# Altium schematic sheet Schematic_Rev1.1.SchDoc
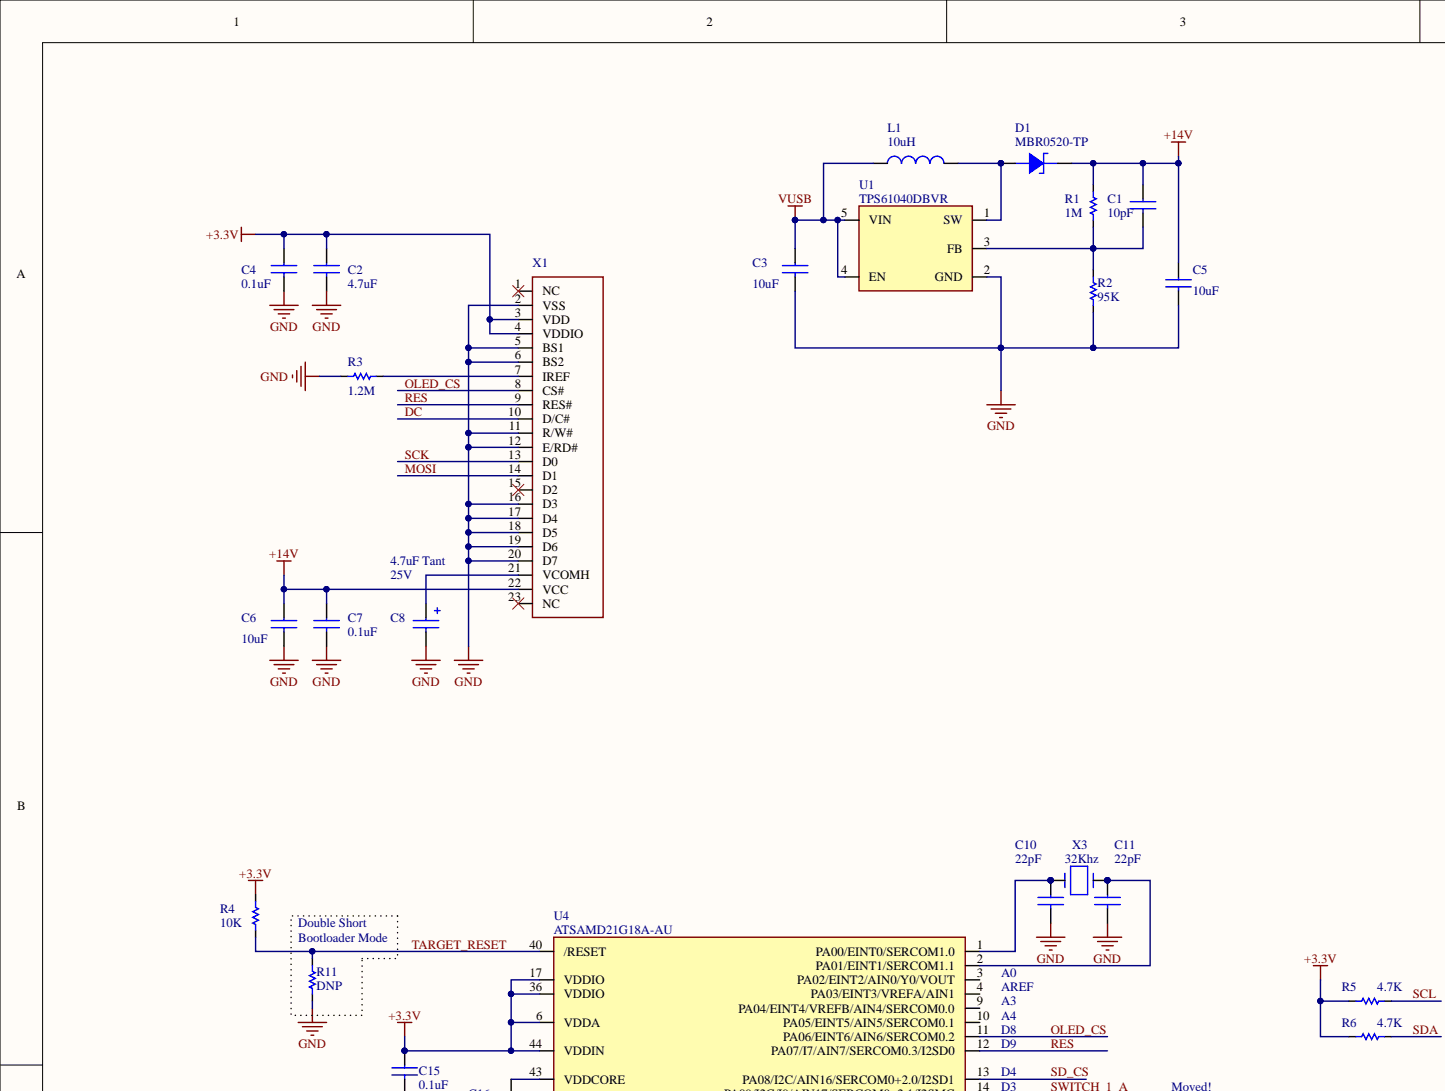
[diagram p0: Schematic_Rev1.1.SchDoc, top left]
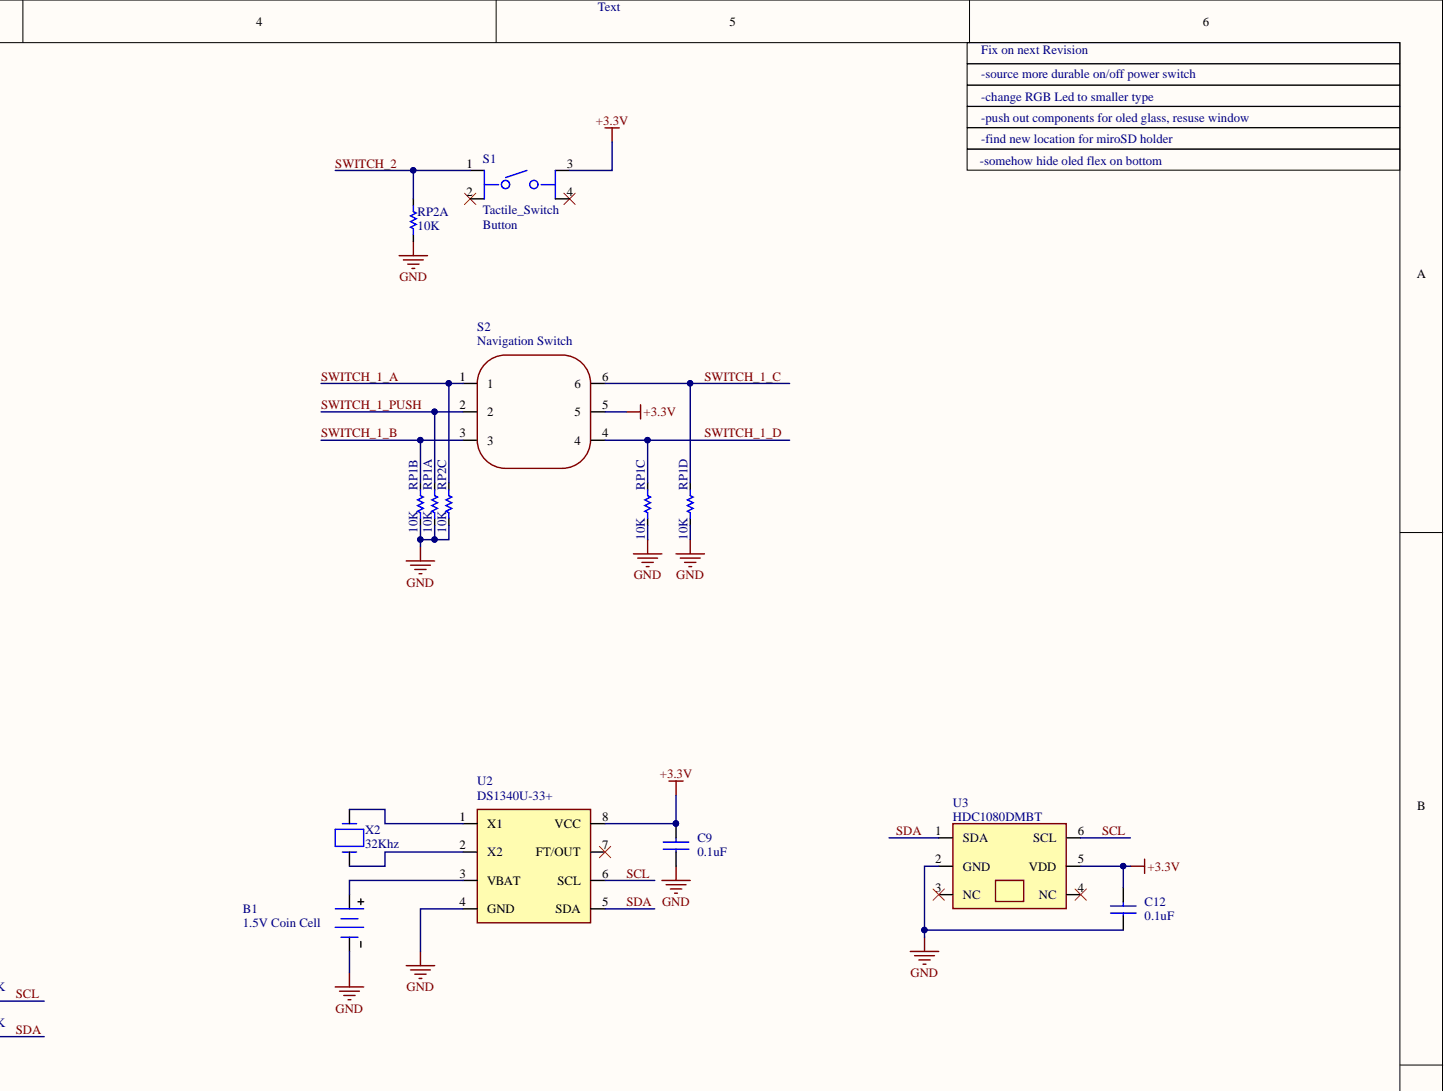
[diagram p1: Schematic_Rev1.1.SchDoc, top right]
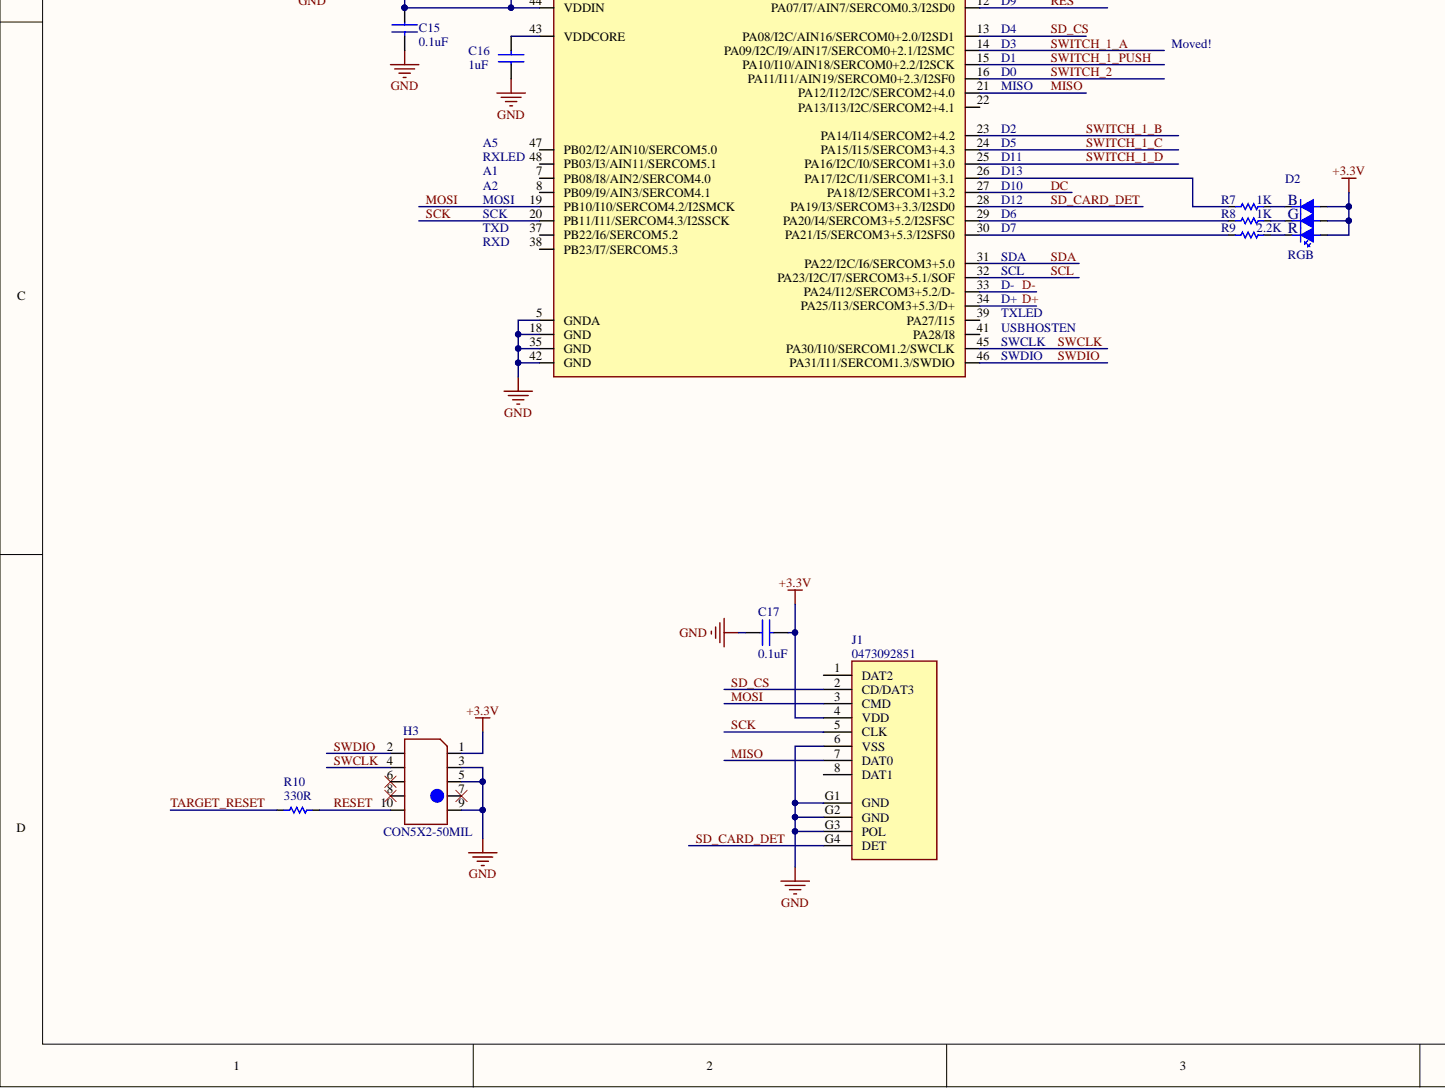
[diagram p2: Schematic_Rev1.1.SchDoc, bottom left]
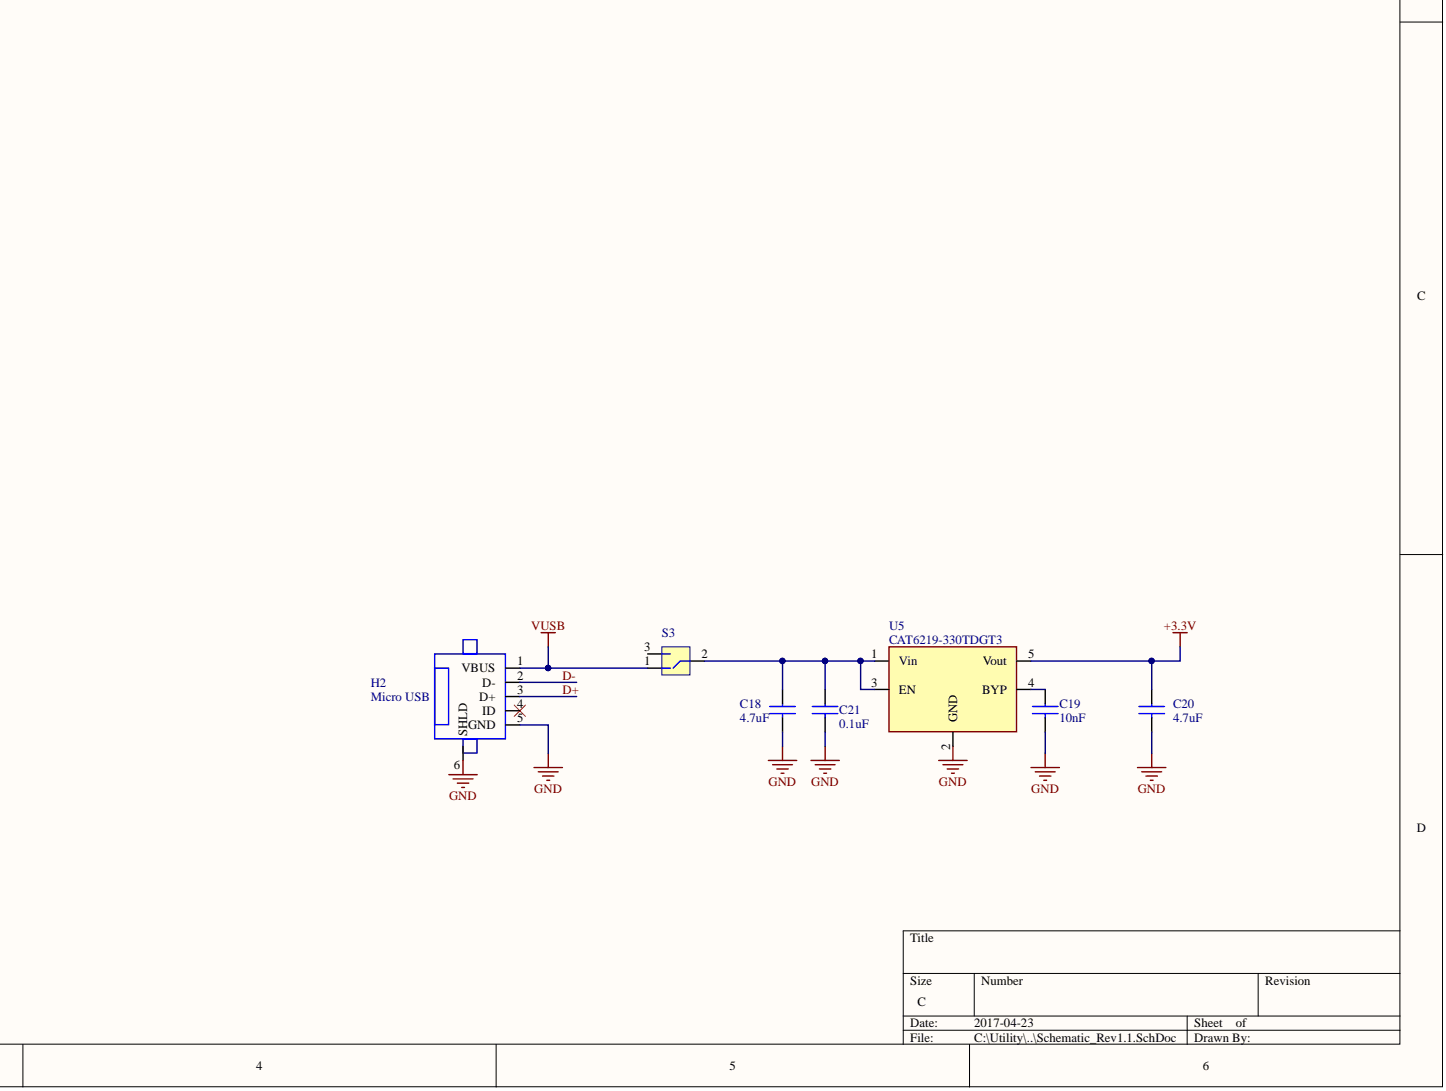
[diagram p3: Schematic_Rev1.1.SchDoc, bottom right]

## Parts
B1  value 1.5V Coin Cell  [CR377 Mount]  (hidden comment: CR377)  (no BOM)
C1  10pF  [CAPC1608X09L]
C2  4.7uF  [CAPC3216X160L]
C3  10uF  [CAPC3216X160L]
C4  0.1uF  [CAPC1608X09L]
C5  10uF  [CAPC3216X160L]
C6  10uF  [CAPC3216X160L]
C7  0.1uF  [CAPC1608X09L]
C8  4.7uF Tant  [CAPC3216X160N_POL]
C9  0.1uF  [CAPC1608X09L]
C10  22pF  [CAPC1608X09L]
C11  22pF  [CAPC1608X09L]
C12  0.1uF  [CAPC1608X09L]
C15  0.1uF  [CAPC1608X09L]
C16  1uF  [CAPC1608X09L]
C17  0.1uF  [CAPC1608X09L]
C18  4.7uF  [CAPC3216X160L]
C19  10nF  [CAPC1608X09L]
C20  4.7uF  [CAPC3216X160L]
C21  0.1uF  [CAPC1608X09L]
D1  MBR0520-TP  [SOD123]
D2  RGB  [E-09-034]
H2  Micro USB  [1981584-1]
H3  CON5X2-50MIL  [HDR5X2-50MIL-SMT]  (no BOM)
J1  0473092851  [0473092851]
L1  value 10uH  [LHQ43C]  (hidden comment: LQH43CN100K03L)
R1  1M  [RESC1608X06L]
R2  95K  [RESC1608X06L]
R3  1.2M  [RESC1608X06L]
R4  10K  [RESC1608X06L]
R5  4.7K  [RESC1608X06L]
R6  4.7K  [RESC1608X06L]
R7  1K  [RESC1608X06L]
R8  1K  [RESC1608X06L]
R9  2.2K  [RESC1608X06L]
R10  330R  [RESC1608X06L]
R11  DNP  [RESC1608X06L]  (no BOM)
RP1  value 10K  [EXB38V]  (hidden comment: EXB38V_SEPERATED)  (parts A,B,C,D)
RP2  value 10K  [EXB38V]  (hidden comment: EXB38V_SEPERATED)  (parts A,C)
S1  Tactile_Switch  value Button  [FSM6JSMA]
S2  Navigation Switch  [NAVIGATION - DX]
S3  SWITCH SLIDE  [SLIDE_SWITCH_JS102000SAQN]  (value not drawn)
U1  TPS61040DBVR  [SOT95P280X144-5N]
U2  DS1340U-33+  [TSOP65P490X110-8N]
U3  HDC1080DMBT  [S-PWSON-N6]
U4  ATSAMD21G18A-AU  [LQFP48]
U5  CAT6219-330TDGT3  [SOT95P280X144-5N]
X1  OLED_GLASS 0.95 inch  [OLED_GLASS 0.96 INCH - new]  (value not drawn)
X2  value 32Khz  [ECS-.327-12.5-34B]  (hidden comment: ECS-.327-12.5-34B)
X3  value 32Khz  [ECS-.327-12.5-34B]  (hidden comment: ECS-.327-12.5-34B)

## Nets
+3.3V: C2.1 C4.1 C9.2 C12.2 C15.1 C17.2 C20.1 D2.4(R) D2.5(G) D2.6 H3.1 J1.4(VDD) R4.1 R5.2 R6.2 S1.3 S2.5 U2.8(VCC) U3.5(VDD) U4.6(VDDA) U4.17(VDDIO) U4.36(VDDIO) U4.44(VDDIN) U5.5(Vout) X1.3(VDD) X1.4(VDDIO)
+14V: C1.1 C5.1 C6.1 C7.1 D1.1 R1.1 X1.22(VCC)
GND: B1.2 C2.2 C3.2 C4.2 C5.2 C6.2 C7.2 C8.2 C9.1 C10.2 C11.2 C12.1 C15.2 C16.1 C17.1 C18.2 C19.2 C20.2 C21.2 H2.5(GND) H2.6(SHLD) H3.3 H3.5 H3.9 J1.6(VSS) J1.G1(GND) J1.G2(GND) J1.G3(POL) R2.2 R3.1 R11.2 RP1.5 RP1.6 RP1.7 RP1.8 RP2.1 RP2.6 U1.2(GND) U2.4(GND) U3.2(GND) U4.5(GNDA) U4.18(GND) U4.35(GND) U4.42(GND) U5.2(GND) X1.2(VSS) X1.5(BS1) X1.6(BS2) X1.11(R/W#) X1.12(E/RD#) X1.16(D3) X1.17(D4) X1.18(D5) X1.19(D6) X1.20(D7)
VUSB: C3.1 H2.1(VBUS) L1.1 S3.1 U1.4(EN) U1.5(VIN)
D+: H2.3(D+) U4.34(PA25/I13/SERCOM3+5.3/D+)
D-: H2.2(D-) U4.33(PA24/I12/SERCOM3+5.2/D-)
DC: U4.27(PA18/I2/SERCOM1+3.2) X1.10(D/C#)
MISO: J1.7(DAT0) U4.21(PA12/I12/I2C/SERCOM2+4.0)
MOSI: J1.3(CMD) U4.19(PB10/I10/SERCOM4.2/I2SMCK) X1.14(D1)
OLED_CS: U4.11(PA06/EINT6/AIN6/SERCOM0.2) X1.8(CS#)
RES: U4.12(PA07/I7/AIN7/SERCOM0.3/I2SD0) X1.9(RES#)
RESET: H3.10 R10.2
SCK: J1.5(CLK) U4.20(PB11/I11/SERCOM4.3/I2SSCK) X1.13(D0)
SCL: R5.1 U2.6(SCL) U3.6(SCL) U4.32(PA23/I2C/I7/SERCOM3+5.1/SOF)
SD_CARD_DET: J1.G4(DET) U4.28(PA19/I3/SERCOM3+3.3/I2SD0)
SD_CS: J1.2(CD/DAT3) U4.13(PA08/I2C/AIN16/SERCOM0+2.0/I2SD1)
SDA: R6.1 U2.5(SDA) U3.1(SDA) U4.31(PA22/I2C/I6/SERCOM3+5.0)
SWCLK: H3.4 U4.45(PA30/I10/SERCOM1.2/SWCLK)
SWDIO: H3.2 U4.46(PA31/I11/SERCOM1.3/SWDIO)
SWITCH_1_A: RP2.3 S2.1 U4.14(PA09/I2C/I9/AIN17/SERCOM0+2.1/I2SMC)
SWITCH_1_B: RP1.2 S2.3 U4.23(PA14/I14/SERCOM2+4.2)
SWITCH_1_C: RP1.4 S2.6 U4.24(PA15/I15/SERCOM3+4.3)
SWITCH_1_D: RP1.3 S2.4 U4.25(PA16/I2C/I0/SERCOM1+3.0)
SWITCH_1_PUSH: RP1.1 S2.2 U4.15(PA10/I10/AIN18/SERCOM0+2.2/I2SCK)
SWITCH_2: RP2.8 S1.1 U4.16(PA11/I11/AIN19/SERCOM0+2.3/I2SF0)
TARGET_RESET: R4.2 R10.1 R11.1 U4.40(/RESET)
NetB1_1: B1.1 U2.3(VBAT)
NetC1_2: C1.2 R1.2 R2.1 U1.3(FB)
NetC8_1: C8.1 X1.21(VCOMH)
NetC10_1: C10.1 U4.1(PA00/EINT0/SERCOM1.0) X3.1
NetC11_1: C11.1 U4.2(PA01/EINT1/SERCOM1.1) X3.2
NetC16_2: C16.2 U4.43(VDDCORE)
NetC18_1: C18.1 C21.1 S3.2 U5.1(Vin) U5.3(EN)
NetC19_1: C19.1 U5.4(BYP)
NetD1_2: D1.2 L1.2 U1.1(SW)
NetD2_1: D2.1 R7.2
NetD2_2: D2.2 R8.2
NetD2_3: D2.3 R9.2
NetR3_2: R3.2 X1.7(IREF)
NetR7_1: R7.1 U4.26(PA17/I2C/I1/SERCOM1+3.1)
NetR8_1: R8.1 U4.29(PA20/I4/SERCOM3+5.2/I2SFSC)
NetR9_1: R9.1 U4.30(PA21/I5/SERCOM3+5.3/I2SFS0)
NetU2_1: U2.1(X1) X2.2
NetU2_2: U2.2(X2) X2.1

Not connected: H2 4(ID); H3 6 7 8; J1 1(DAT2) 8(DAT1); S1 2 4; S3 3; U2 7(FT/OUT); U3 3(NC) 4(NC); U4 3(PA02/EINT2/AIN0/Y0/VOUT) 4(PA03/EINT3/VREFA/AIN1) 7(PB08/I8/AIN2/SERCOM4.0) 8(PB09/I9/AIN3/SERCOM4.1) 9(PA04/EINT4/VREFB/AIN4/SERCOM0.0) 10(PA05/EINT5/AIN5/SERCOM0.1) 22(PA13/I13/I2C/SERCOM2+4.1) 37(PB22/I6/SERCOM5.2) 38(PB23/I7/SERCOM5.3) 39(PA27/I15) 41(PA28/I8) 47(PB02/I2/AIN10/SERCOM5.0) 48(PB03/I3/AIN11/SERCOM5.1); X1 1(NC) 15(D2) 23(NC)

## Library data of the parts
B1 = CR377: Custom CR377 mount; Manufacturer: Sunbeam; Vendor: DX; Library Name: MAR.SchLib
C1 = CAP_0603: 10pF 50V Ceramic Capacitor C0G; Manufacturer: Kemet; PartNumber: C0603C100J5GACTU; Vendor: Digi-Key; Component Kind: Standard; Value: 10pF; Library Name: MAR.SchLib
C2 = CAP_1206: CAP CER 4.7UF 16V 10% X7R 1206; Manufacturer: Samsung; PartNumber: CL31B475KOHNFNE; Vendor: Digi-Key; Component Kind: Standard; Value: 4.7uF; Library Name: MAR.SchLib
C3 C5 C6 = CAP_1206: CAP CER 10UF 16V 10% X7R 1206; Manufacturer: Samsung; PartNumber: CL31B106KOHNNNE; Vendor: Digi-Key; Component Kind: Standard; Value: 10uF; Library Name: MAR.SchLib
C4 C7 = CAP_0603: CAP CER 0.1UF 16V 10% X7R 0603; Manufacturer: Murata; PartNumber: GRM188R71C104KA01D; Vendor: Digi-Key; Component Kind: Standard; Library Name: Parts_Library.IntLib; Value: 0.1uF
C8 = CAP_TANT_1206: 4.7µF Molded Tantalum Capacitors; Manufacturer: Kemet; PartNumber: T491A475M025AT; Vendor: Digi-Key; Component Kind: Standard; Value: 4.7uF Tant; Library Name: MAR.SchLib
C9 C12 C15 C17 C21 = CAP_0603: CAP, 0.1uF, 25V, 0603; Manufacturer: Murata; PartNumber: GRM188R71E104KA01D; Vendor: Digi-Key; Component Kind: Standard; Value: 0.1uF; Library Name: MAR.SchLib
C10 C11 = CAP_0603: CAP, 22pF, 0603; Manufacturer: Murata; PartNumber: GRM1885C1H220JA01D; Vendor: Digi-Key; Component Kind: Standard; Value: 22pF; Library Name: MAR.SchLib
C16 = CAP_0603: CAP, 1uF, 25V, 0603; Manufacturer: Murata; PartNumber: GRM188R71E105KA12D; Vendor: Digi-Key; Component Kind: Standard; Value: 1uF; Library Name: MAR.SchLib
C18 C20 = CAP_1206: CAP, 4.7uF, 25V, 1206; Manufacturer: Murata; PartNumber: GRM31CR71E475KA88L; Vendor: Digi-Key; Component Kind: Standard; Value: 4.7uF; Library Name: MAR.SchLib
C19 = CAP_0603: CAP CER 0603 10NF 50V X7R 10%; Manufacturer: Kemet; PartNumber: C0603C103K5REC7867; Vendor: Digi-Key; Component Kind: Standard; Value: 10nF; Library Name: MAR.SchLib
D1 = MBR0520-TP: DIODE SCHOTTKY 20V 500MA SOD123; Manufacturer: Micro; Vendor: Digikey; Component Kind: Standard; PartNumber: MBR0520-TP; Library Name: MAR.SchLib
D2 = E-09-034: LED,RGB,20mA,6SMD,RoHS; Manufacturer: BROADCOM; PartNumber: ASMT-YTB7-0AA02; Vendor: Digi-Key; Component Kind: Standard; Library Name: ACPartNumbers.SchLib
H2 = 1981584-1: CONN RCPT MICRO USB TYPE AB; Manufacturer: TE Connectivity; Vendor: Digi-Key; Component Kind: Standard; PartNumber: 1981584-1; Value: Micro USB; Library Name: MAR.SchLib
H3 = CON5X2_50mil: JTAG CONN HEADER 5X2POS 50mil" PADS; PartNumber: PADS; Value: JTAG CONN HEADER 5X2POS 50mil" PADS; Library Name: MAR.SchLib
J1 = 0473092851: 9 (8 + 1) microSD SMT, Right Angle; Manufacturer: Molex; Vendor: Digi-Key; Component Kind: Standard; PartNumber: 0473092851; Library Name: MAR.SchLib
L1 = LQH43CN100K03L: INDUCTOR 10UH 10% 650MA 1812; Manufacturer: Murata; Vendor: Digikey; Component Kind: Standard; PartNumber: LQH43CN100K03L; Library Name: MAR.SchLib
R1 = RES_0603: RES SMD 1M OHM 1% 1/10W 0603; Manufacturer: Panasonic; PartNumber: ERJ-3EKF1004V; Vendor: Digi-Key; Component Kind: Standard; Value: 1M; Library Name: MAR.SchLib
R2 = RES_0603: RES SMD 95.3K OHM 1% 1/10W 0603; Manufacturer: Yageo; PartNumber: RC0603FR-0795K3L; Vendor: Digi-Key; Component Kind: Standard; Value: 95K; Library Name: MAR.SchLib
R3 = RES_0603: RES SMD 1.2M OHM 1% 1/10W 0603; Manufacturer: Panasonic; PartNumber: ERJ-3EKF1204V; Vendor: Digi-Key; Component Kind: Standard; Value: 1.2M; Library Name: MAR.SchLib
R4 = RES_0603: RES SMD 10K OHM 1% 1/10W 0603; Manufacturer: Panasonic; PartNumber: ERJ-3EKF1002V; Vendor: Digi-Key; Component Kind: Standard; Value: 10K; Library Name: MAR.SchLib
R5 R6 = RES_0603: RES SMD 4.7K OHM 1% 1/4W 0603; Manufacturer: Panasonic; PartNumber: ERJ-PA3F4701V; Vendor: Digi-Key; Component Kind: Standard; Value: 4.7K; Library Name: MAR.SchLib
R7 R8 = RES_0603: RES SMD 1K OHM 1% 1/10W 0603; Manufacturer: Panasonic; PartNumber: ERJ-3EKF1001V; Vendor: Digi-Key; Component Kind: Standard; Value: 1K; Library Name: MAR.SchLib
R9 = RES_0603: RES SMD 2.2K OHM 1% 1/10W 0603; Manufacturer: Panasonic; PartNumber: ERJ-3EKF2201V; Vendor: Digi-Key; Component Kind: Standard; Value: 2.2K; Library Name: MAR.SchLib
R10 = RES_0603: RES, 330R, 0603; Manufacturer: Panasonic; PartNumber: ERJ-3EKF3300V; Vendor: Digi-Key; Component Kind: Standard; Value: 330R; Library Name: MAR.SchLib
R11 = RES_0603: RES 0603; Value: DNP; Library Name: MAR.SchLib
RP1 RP2 = EXB38V_SEPERATED: RES ARRAY 4 RES 10K OHM 1206; Manufacturer: Panasonic; PartNumber: EXB-38V103JV; Vendor: Digi-Key; Component Kind: Standard; Library Name: MAR.SchLib
S1 = FSM6JSMA: SWITCH TACTILE SPST-NO 0.05A 12V; Manufacturer: TE Connectivity; Vendor: Digi-Key; Component Kind: Standard; PartNumber: FSM6JSMA; Library Name: MAR.SchLib
S2 = DX_NAV: DX_Navigation Switch; PartNumber: 122745; Value: DX_Navigation_Switch; Vendor: DX.com; Component Kind: Standard; Library Name: MAR.SchLib
S3 = JS102000SAQN: SWITCH SLIDE SPDT R/A; Manufacturer: C&K; Vendor: Digi-Key; Component Kind: Standard; PartNumber: JS102000SAQN; Value: SWITCH SLIDE; Library Name: MAR.SchLib
U1 = TPS61040DBVR: IC LED DRVR WHITE BCKLGT SOT23-5; Manufacturer: Texas Instruments; Vendor: Digi-key; Component Kind: Standard; PartNumber: TPS61040DBVR; Library Name: MAR.SchLib
U2 = DS1340U-33+: IC RTC SERIAL 512K 8-TSSOP; Manufacturer: Maxim; Vendor: Digi-Key; Component Kind: Standard; PartNumber: DS1340U-33+; Library Name: MAR.SchLib
U3 = HDC1080DMBT: Humidity/Temperature Sensor; Manufacturer: Texas Instruments; Vendor: Digi-Key; Component Kind: Standard; PartNumber: HDC1080DMBT; Library Name: MAR.SchLib
U4 = ATSAMD21G18A-AU: IC MCU 32BIT 256KB FLASH 48-TQFP; Manufacturer: Atmel; Vendor: Digi-Key; Component Kind: Standard; PartNumber: ATSAMD21G18A-AU; Library Name: MAR.SchLib
U5 = CAT6219-330TDGT3: IC REG LDO 3.3V 0.5A SOT23-5; Manufacturer: On Semi; Vendor: Digi-Key; Component Kind: Standard; PartNumber: CAT6219-330TDGT3; Library Name: MAR.SchLib
X1 = OLED_GLASS 0.95 INCH COLOR_1: 0.95 INCH OLED GLASS COLOR; Manufacturer: Buy-Display; PartNumber: ER-OLED0.95-1; Component Kind: Standard; Vendor: Buy-Display; Library Name: MAR.SchLib
X2 X3 = ECS-.327-12.5-34B: CRYSTAL 32.768 KHZ 12.5PF SMD; Manufacturer: ECS; Vendor: Digi-Key; Component Kind: Standard; PartNumber: ECS-.327-12.5-34B; Library Name: MAR.SchLib
